# Altium schematic sheet Power outputs.SchDoc("Power outputs")
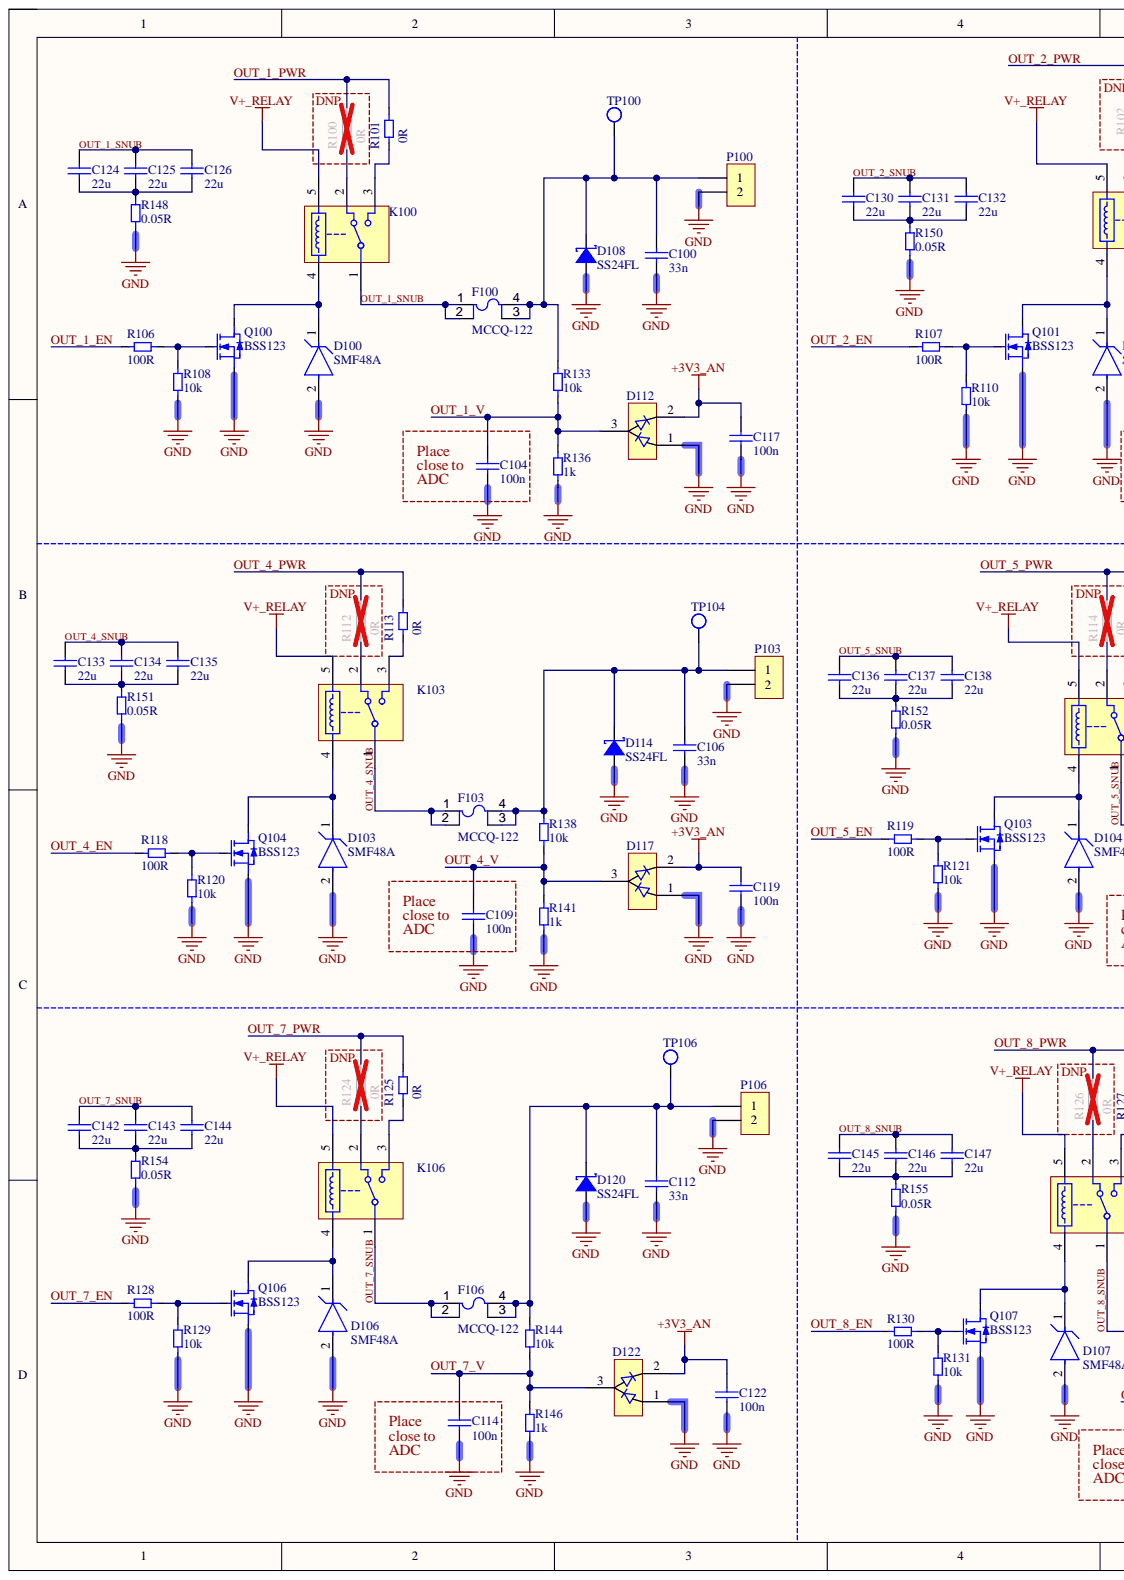
[diagram p0: Power outputs.SchDoc, left]
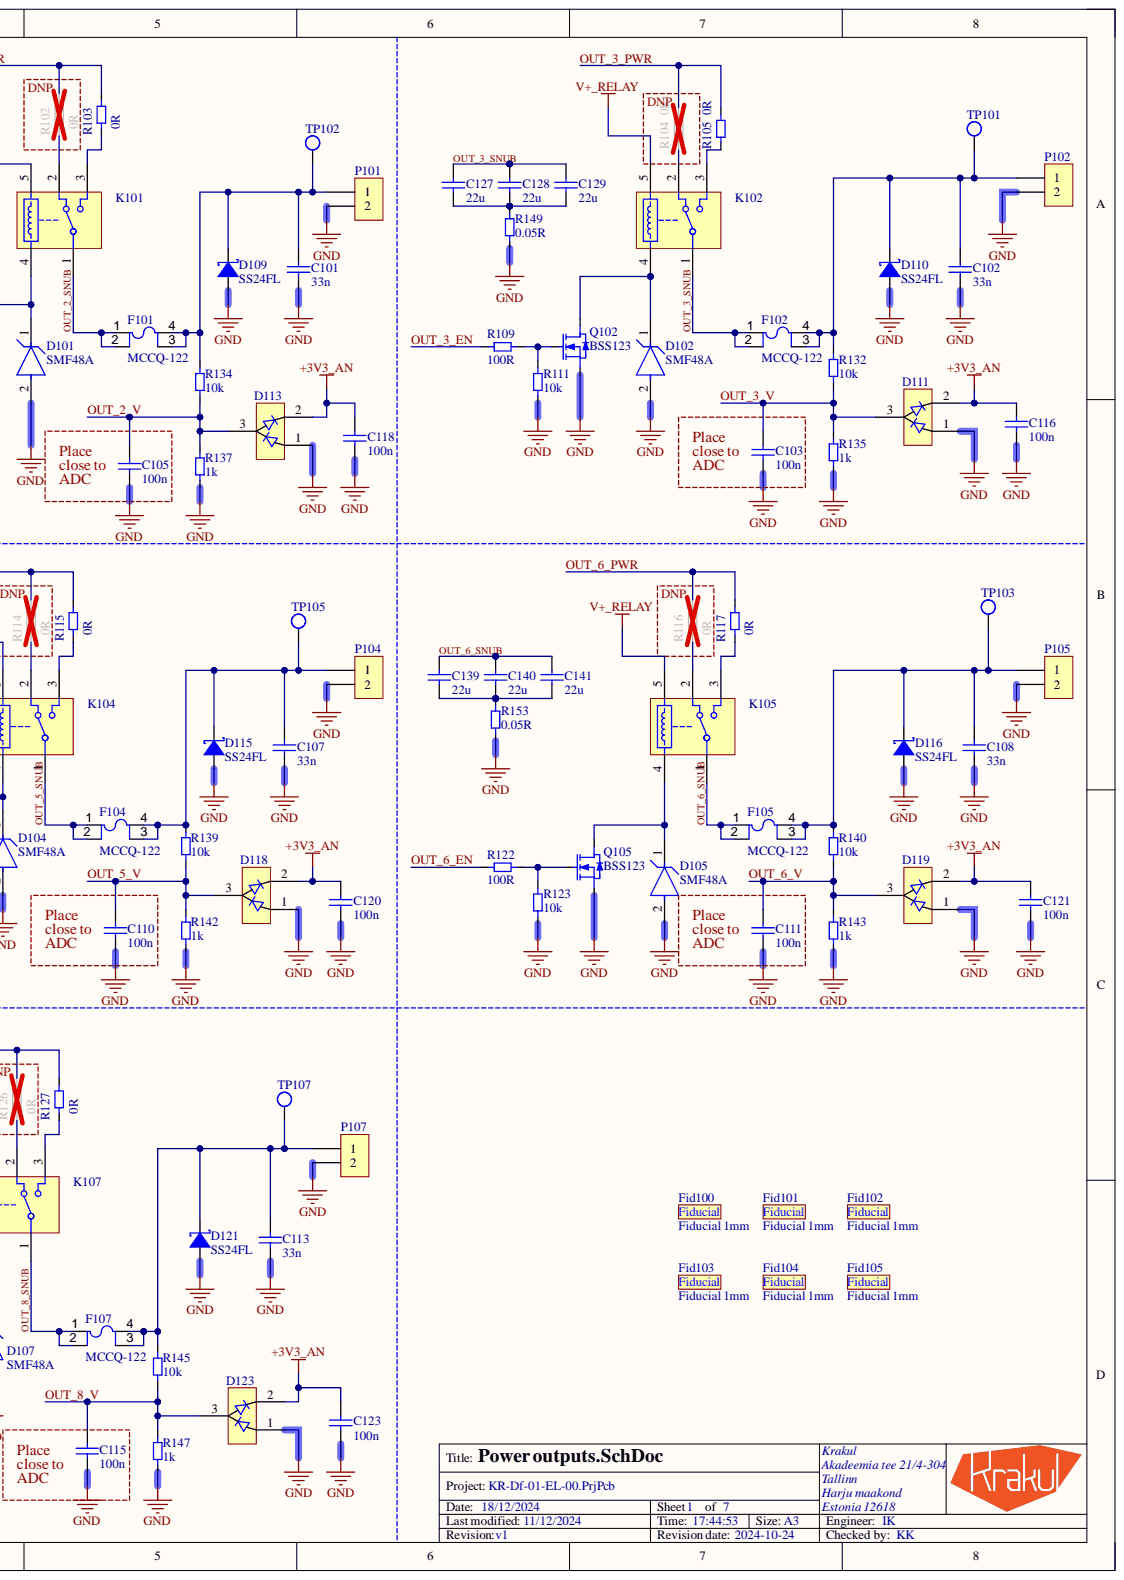
[diagram p1: Power outputs.SchDoc, right]

## Parts
C100  33n  [MLCC_0805_M]
C101  33n  [MLCC_0805_M]
C102  33n  [MLCC_0805_M]
C103  100n  [MLCC_0402_M]
C104  100n  [MLCC_0402_M]
C105  100n  [MLCC_0402_M]
C106  33n  [MLCC_0805_M]
C107  33n  [MLCC_0805_M]
C108  33n  [MLCC_0805_M]
C109  100n  [MLCC_0402_M]
C110  100n  [MLCC_0402_M]
C111  100n  [MLCC_0402_M]
C112  33n  [MLCC_0805_M]
C113  33n  [MLCC_0805_M]
C114  100n  [MLCC_0402_M]
C115  100n  [MLCC_0402_M]
C116  100n  [MLCC_0402_M]
C117  100n  [MLCC_0402_M]
C118  100n  [MLCC_0402_M]
C119  100n  [MLCC_0402_M]
C120  100n  [MLCC_0402_M]
C121  100n  [MLCC_0402_M]
C122  100n  [MLCC_0402_M]
C123  100n  [MLCC_0402_M]
C124  22u  [MLCC_0805_M]
C125  22u  [MLCC_0805_M]
C126  22u  [MLCC_0805_M]
C127  22u  [MLCC_0805_M]
C128  22u  [MLCC_0805_M]
C129  22u  [MLCC_0805_M]
C130  22u  [MLCC_0805_M]
C131  22u  [MLCC_0805_M]
C132  22u  [MLCC_0805_M]
C133  22u  [MLCC_0805_M]
C134  22u  [MLCC_0805_M]
C135  22u  [MLCC_0805_M]
C136  22u  [MLCC_0805_M]
C137  22u  [MLCC_0805_M]
C138  22u  [MLCC_0805_M]
C139  22u  [MLCC_0805_M]
C140  22u  [MLCC_0805_M]
C141  22u  [MLCC_0805_M]
C142  22u  [MLCC_0805_M]
C143  22u  [MLCC_0805_M]
C144  22u  [MLCC_0805_M]
C145  22u  [MLCC_0805_M]
C146  22u  [MLCC_0805_M]
C147  22u  [MLCC_0805_M]
D100  SMF48A  [FP-SMF48A-MFG]
D101  SMF48A  [FP-SMF48A-MFG]
D102  SMF48A  [FP-SMF48A-MFG]
D103  SMF48A  [FP-SMF48A-MFG]
D104  SMF48A  [FP-SMF48A-MFG]
D105  SMF48A  [FP-SMF48A-MFG]
D106  SMF48A  [FP-SMF48A-MFG]
D107  SMF48A  [FP-SMF48A-MFG]
D108  SS24FL  [SOD-123F]
D109  SS24FL  [SOD-123F]
D110  SS24FL  [SOD-123F]
D111  BAT6404WH6327XTSA1  [SOT323, SC70]  (value not drawn)
D112  BAT6404WH6327XTSA1  [SOT323, SC70]  (value not drawn)
D113  BAT6404WH6327XTSA1  [SOT323, SC70]  (value not drawn)
D114  SS24FL  [SOD-123F]
D115  SS24FL  [SOD-123F]
D116  SS24FL  [SOD-123F]
D117  BAT6404WH6327XTSA1  [SOT323, SC70]  (value not drawn)
D118  BAT6404WH6327XTSA1  [SOT323, SC70]  (value not drawn)
D119  BAT6404WH6327XTSA1  [SOT323, SC70]  (value not drawn)
D120  SS24FL  [SOD-123F]
D121  SS24FL  [SOD-123F]
D122  BAT6404WH6327XTSA1  [SOT323, SC70]  (value not drawn)
D123  BAT6404WH6327XTSA1  [SOT323, SC70]  (value not drawn)
F100  MCCQ-122  [MCCQ-122-Footprint-1]
F101  MCCQ-122  [MCCQ-122-Footprint-1]
F102  MCCQ-122  [MCCQ-122-Footprint-1]
F103  MCCQ-122  [MCCQ-122-Footprint-1]
F104  MCCQ-122  [MCCQ-122-Footprint-1]
F105  MCCQ-122  [MCCQ-122-Footprint-1]
F106  MCCQ-122  [MCCQ-122-Footprint-1]
F107  MCCQ-122  [MCCQ-122-Footprint-1]
Fid100  Fiducial 1mm  [Fiducial 1mm]
Fid101  Fiducial 1mm  [Fiducial 1mm]
Fid102  Fiducial 1mm  [Fiducial 1mm]
Fid103  Fiducial 1mm  [Fiducial 1mm]
Fid104  Fiducial 1mm  [Fiducial 1mm]
Fid105  Fiducial 1mm  [Fiducial 1mm]
K100  J107F1CS205VDC.45  [J107F1CS205VDC.45]  (value not drawn)
K101  J107F1CS205VDC.45  [J107F1CS205VDC.45]  (value not drawn)
K102  J107F1CS205VDC.45  [J107F1CS205VDC.45]  (value not drawn)
K103  J107F1CS205VDC.45  [J107F1CS205VDC.45]  (value not drawn)
K104  J107F1CS205VDC.45  [J107F1CS205VDC.45]  (value not drawn)
K105  J107F1CS205VDC.45  [J107F1CS205VDC.45]  (value not drawn)
K106  J107F1CS205VDC.45  [J107F1CS205VDC.45]  (value not drawn)
K107  J107F1CS205VDC.45  [J107F1CS205VDC.45]  (value not drawn)
P100  DGPS2.5R-5.0-02P  [DGPS2.5R-5.0-02P-Footprint-1]  (value not drawn)
P101  DGPS2.5R-5.0-02P  [DGPS2.5R-5.0-02P-Footprint-1]  (value not drawn)
P102  DGPS2.5R-5.0-02P  [DGPS2.5R-5.0-02P-Footprint-1]  (value not drawn)
P103  DGPS2.5R-5.0-02P  [DGPS2.5R-5.0-02P-Footprint-1]  (value not drawn)
P104  DGPS2.5R-5.0-02P  [DGPS2.5R-5.0-02P-Footprint-1]  (value not drawn)
P105  DGPS2.5R-5.0-02P  [DGPS2.5R-5.0-02P-Footprint-1]  (value not drawn)
P106  DGPS2.5R-5.0-02P  [DGPS2.5R-5.0-02P-Footprint-1]  (value not drawn)
P107  DGPS2.5R-5.0-02P  [DGPS2.5R-5.0-02P-Footprint-1]  (value not drawn)
Q100  BSS123  [SOT-23-3]
Q101  BSS123  [SOT-23-3]
Q102  BSS123  [SOT-23-3]
Q103  BSS123  [SOT-23-3]
Q104  BSS123  [SOT-23-3]
Q105  BSS123  [SOT-23-3]
Q106  BSS123  [SOT-23-3]
Q107  BSS123  [SOT-23-3]
R100  Value 2: 0R  [TLRZ2BTTD-Footprint-1]  (hidden comment: TLRZ2BTTD)
R101  Value 2: 0R  [TLRZ2BTTD-Footprint-1]  (hidden comment: TLRZ2BTTD)
R102  TLRZ2BTTD  [TLRZ2BTTD-Footprint-1]  (value not drawn)
R103  Value 2: 0R  [TLRZ2BTTD-Footprint-1]  (hidden comment: TLRZ2BTTD)
R104  Value 2: 0R  [TLRZ2BTTD-Footprint-1]  (hidden comment: TLRZ2BTTD)
R105  Value 2: 0R  [TLRZ2BTTD-Footprint-1]  (hidden comment: TLRZ2BTTD)
R106  100R  [Resistor_0603_M]
R107  100R  [Resistor_0603_M]
R108  10k  [Resistor_0603_M]
R109  100R  [Resistor_0603_M]
R110  10k  [Resistor_0603_M]
R111  10k  [Resistor_0603_M]
R112  TLRZ2BTTD  [TLRZ2BTTD-Footprint-1]  (value not drawn)
R113  Value 2: 0R  [TLRZ2BTTD-Footprint-1]  (hidden comment: TLRZ2BTTD)
R114  Value 2: 0R  [TLRZ2BTTD-Footprint-1]  (hidden comment: TLRZ2BTTD)
R115  Value 2: 0R  [TLRZ2BTTD-Footprint-1]  (hidden comment: TLRZ2BTTD)
R116  Value 2: 0R  [TLRZ2BTTD-Footprint-1]  (hidden comment: TLRZ2BTTD)
R117  Value 2: 0R  [TLRZ2BTTD-Footprint-1]  (hidden comment: TLRZ2BTTD)
R118  100R  [Resistor_0603_M]
R119  100R  [Resistor_0603_M]
R120  10k  [Resistor_0603_M]
R121  10k  [Resistor_0603_M]
R122  100R  [Resistor_0603_M]
R123  10k  [Resistor_0603_M]
R124  TLRZ2BTTD  [TLRZ2BTTD-Footprint-1]  (value not drawn)
R125  Value 2: 0R  [TLRZ2BTTD-Footprint-1]  (hidden comment: TLRZ2BTTD)
R126  Value 2: 0R  [TLRZ2BTTD-Footprint-1]  (hidden comment: TLRZ2BTTD)
R127  Value 2: 0R  [TLRZ2BTTD-Footprint-1]  (hidden comment: TLRZ2BTTD)
R128  100R  [Resistor_0603_M]
R129  10k  [Resistor_0603_M]
R130  100R  [Resistor_0603_M]
R131  10k  [Resistor_0603_M]
R132  10k  [Resistor_0603_M]
R133  10k  [Resistor_0603_M]
R134  10k  [Resistor_0603_M]
R135  1k  [Resistor_0603_M]
R136  1k  [Resistor_0603_M]
R137  1k  [Resistor_0603_M]
R138  10k  [Resistor_0603_M]
R139  10k  [Resistor_0603_M]
R140  10k  [Resistor_0603_M]
R141  1k  [Resistor_0603_M]
R142  1k  [Resistor_0603_M]
R143  1k  [Resistor_0603_M]
R144  10k  [Resistor_0603_M]
R145  10k  [Resistor_0603_M]
R146  1k  [Resistor_0603_M]
R147  1k  [Resistor_0603_M]
R148  0.05R  [0805 resisitor based on RCWE080550L0FNEA]
R149  0.05R  [0805 resisitor based on RCWE080550L0FNEA]
R150  0.05R  [0805 resisitor based on RCWE080550L0FNEA]
R151  0.05R  [0805 resisitor based on RCWE080550L0FNEA]
R152  0.05R  [0805 resisitor based on RCWE080550L0FNEA]
R153  0.05R  [0805 resisitor based on RCWE080550L0FNEA]
R154  0.05R  [0805 resisitor based on RCWE080550L0FNEA]
R155  0.05R  [0805 resisitor based on RCWE080550L0FNEA]
TP100  Testpoint 1.2mm  [Testpoint 1.2mm]  (value not drawn)  (no BOM)
TP101  Testpoint 1.2mm  [Testpoint 1.2mm]  (value not drawn)  (no BOM)
TP102  Testpoint 1.2mm  [Testpoint 1.2mm]  (value not drawn)  (no BOM)
TP103  Testpoint 1.2mm  [Testpoint 1.2mm]  (value not drawn)  (no BOM)
TP104  Testpoint 1.2mm  [Testpoint 1.2mm]  (value not drawn)  (no BOM)
TP105  Testpoint 1.2mm  [Testpoint 1.2mm]  (value not drawn)  (no BOM)
TP106  Testpoint 1.2mm  [Testpoint 1.2mm]  (value not drawn)  (no BOM)
TP107  Testpoint 1.2mm  [Testpoint 1.2mm]  (value not drawn)  (no BOM)

## Nets
+3V3_AN: C116.2 C117.2 C118.2 C119.2 C120.2 C121.2 C122.2 C123.2 D111.2 D112.2 D113.2 D117.2 D118.2 D119.2 D122.2 D123.2
GND: C100.1 C101.1 C102.1 C103.1 C104.1 C105.1 C106.1 C107.1 C108.1 C109.1 C110.1 C111.1 C112.1 C113.1 C114.1 C115.1 C116.1 C117.1 C118.1 C119.1 C120.1 C121.1 C122.1 C123.1 D100.2 D101.2 D102.2 D103.2 D104.2 D105.2 D106.2 D107.2 D108.2 D109.2 D110.2 D111.1 D112.1 D113.1 D114.2 D115.2 D116.2 D117.1 D118.1 D119.1 D120.2 D121.2 D122.1 D123.1 P100.2 P101.2 P102.2 P103.2 P104.2 P105.2 P106.2 P107.2 Q100.2 Q101.2 Q102.2 Q103.2 Q104.2 Q105.2 Q106.2 Q107.2 R108.1 R110.1 R111.1 R120.1 R121.1 R123.1 R129.1 R131.1 R135.1 R136.1 R137.1 R141.1 R142.1 R143.1 R146.1 R147.1 R148.1 R149.1 R150.1 R151.1 R152.1 R153.1 R154.1 R155.1
V+_RELAY: K100.5 K101.5 K102.5 K103.5 K104.5 K105.5 K106.5 K107.5
OUT_1_EN: R106.1
OUT_1_PWR: R100.2 R101.2
OUT_1_SNUB: C124.2 C125.2 C126.2 F100.1 F100.2 K100.1
OUT_1_V: C104.2 D112.3 R133.1 R136.2
OUT_2_EN: R107.1
OUT_2_PWR: R102.2 R103.2
OUT_2_SNUB: C130.2 C131.2 C132.2 F101.1 F101.2 K101.1
OUT_2_V: C105.2 D113.3 R134.1 R137.2
OUT_3_EN: R109.1
OUT_3_PWR: R104.2 R105.2
OUT_3_SNUB: C127.2 C128.2 C129.2 F102.1 F102.2 K102.1
OUT_3_V: C103.2 D111.3 R132.1 R135.2
OUT_4_EN: R118.1
OUT_4_PWR: R112.2 R113.2
OUT_4_SNUB: C133.2 C134.2 C135.2 F103.1 F103.2 K103.1
OUT_4_V: C109.2 D117.3 R138.1 R141.2
OUT_5_EN: R119.1
OUT_5_PWR: R114.2 R115.2
OUT_5_SNUB: C136.2 C137.2 C138.2 F104.1 F104.2 K104.1
OUT_5_V: C110.2 D118.3 R139.1 R142.2
OUT_6_EN: R122.1
OUT_6_PWR: R116.2 R117.2
OUT_6_SNUB: C139.2 C140.2 C141.2 F105.1 F105.2 K105.1
OUT_6_V: C111.2 D119.3 R140.1 R143.2
OUT_7_EN: R128.1
OUT_7_PWR: R124.2 R125.2
OUT_7_SNUB: C142.2 C143.2 C144.2 F106.1 F106.2 K106.1
OUT_7_V: C114.2 D122.3 R144.1 R146.2
OUT_8_EN: R130.1
OUT_8_PWR: R126.2 R127.2
OUT_8_SNUB: C145.2 C146.2 C147.2 F107.1 F107.2 K107.1
OUT_8_V: C115.2 D123.3 R145.1 R147.2
NetC100_2: C100.2 D108.1 F100.3 F100.4 P100.1 R133.2 TP100.1
NetC101_2: C101.2 D109.1 F101.3 F101.4 P101.1 R134.2 TP102.1
NetC102_2: C102.2 D110.1 F102.3 F102.4 P102.1 R132.2 TP101.1
NetC106_2: C106.2 D114.1 F103.3 F103.4 P103.1 R138.2 TP104.1
NetC107_2: C107.2 D115.1 F104.3 F104.4 P104.1 R139.2 TP105.1
NetC108_2: C108.2 D116.1 F105.3 F105.4 P105.1 R140.2 TP103.1
NetC112_2: C112.2 D120.1 F106.3 F106.4 P106.1 R144.2 TP106.1
NetC113_2: C113.2 D121.1 F107.3 F107.4 P107.1 R145.2 TP107.1
NetC124_1: C124.1 C125.1 C126.1 R148.2
NetC127_1: C127.1 C128.1 C129.1 R149.2
NetC130_1: C130.1 C131.1 C132.1 R150.2
NetC133_1: C133.1 C134.1 C135.1 R151.2
NetC136_1: C136.1 C137.1 C138.1 R152.2
NetC139_1: C139.1 C140.1 C141.1 R153.2
NetC142_1: C142.1 C143.1 C144.1 R154.2
NetC145_1: C145.1 C146.1 C147.1 R155.2
NetD100_1: D100.1 K100.4 Q100.3
NetD101_1: D101.1 K101.4 Q101.3
NetD102_1: D102.1 K102.4 Q102.3
NetD103_1: D103.1 K103.4 Q104.3
NetD104_1: D104.1 K104.4 Q103.3
NetD105_1: D105.1 K105.4 Q105.3
NetD106_1: D106.1 K106.4 Q106.3
NetD107_1: D107.1 K107.4 Q107.3
NetK100_2: K100.2 R100.1
NetK100_3: K100.3 R101.1
NetK101_2: K101.2 R102.1
NetK101_3: K101.3 R103.1
NetK102_2: K102.2 R104.1
NetK102_3: K102.3 R105.1
NetK103_2: K103.2 R112.1
NetK103_3: K103.3 R113.1
NetK104_2: K104.2 R114.1
NetK104_3: K104.3 R115.1
NetK105_2: K105.2 R116.1
NetK105_3: K105.3 R117.1
NetK106_2: K106.2 R124.1
NetK106_3: K106.3 R125.1
NetK107_2: K107.2 R126.1
NetK107_3: K107.3 R127.1
NetQ100_1: Q100.1 R106.2 R108.2
NetQ101_1: Q101.1 R107.2 R110.2
NetQ102_1: Q102.1 R109.2 R111.2
NetQ103_1: Q103.1 R119.2 R121.2
NetQ104_1: Q104.1 R118.2 R120.2
NetQ105_1: Q105.1 R122.2 R123.2
NetQ106_1: Q106.1 R128.2 R129.2
NetQ107_1: Q107.1 R130.2 R131.2

## Library data of the parts
C100-C102 C106-C108 C112 C113 = CMP-001-1076-2: MLCC, 0805, 33n, 10%, 50V, X7R; Manufacturer: Any; Capacitor Type: Ceramic; Value: 33nF; Case/Package: 0805; Component Kind: Standard; Dielectric: X7R; Mount: SMD; Part number: MLCC, 0805, 33n, 10%, 50V, X7R; RoHS: Yes; Tolerance: 10%; Voltage: 50V; Library Name: Krakul Vault
C103-C105 C109-C111 C114-C123 = CMP-001-1256-2: MLCC, 0402, 100n, 10%, 10V, X5R; Manufacturer: Any; Capacitor Type: Ceramic; Value: 100nF; Case/Package: 0402; Component Kind: Standard; Dielectric: X5R; Mount: SMD; Part number: MLCC, 0402, 100n, 10%, 10V, X5R; RoHS: Yes; Tolerance: 10%; Voltage: 10V; Library Name: Krakul Vault
C124-C147 = CMP-001-1665-1: MLCC, 0805, 22u, 20%, 35V, X5R; Manufacturer: TDK; Part number: C2012X5R1V226M125AC; Capacitor Type: Ceramic; Value: 22µF; Case/Package: 0805; Component Kind: Standard; Dielectric: X5R; Mount: SMD; RoHS: Yes; Tolerance: 20%; Voltage: 35V; Library Name: Krakul Vault
D100-D107 = CMP-ROOT-01053-2: Diode TVS Single Uni-Dir 48V 200W 2-Pin SOD-123F T/R; Manufacturer: Littelfuse; Breakdown Voltage: 58.9V; Clamping Voltage: 77.4V; Diode Type: ZENER; Max Surge Current: 2.6A; Min Breakdown Voltage: 53.3V; Number of Channels: 1; Polarity: Unidirectional; Power Line Protection: No; Case/Package: SMF; Component Kind: Standard; Lead Free: Contains Lead; Max Operating Temperature: 150°C; Min Operating Temperature: -55°C; Mount: SMD; Mounting Technology: Surface Mount; Number of Unidirectional Channels: 1; Packaging: Tape and Reel; Part number: SMF48A; Peak Pulse Current: 2.6A; Peak Pulse Power: 200W; Pins: 2; Reverse Standoff Voltage: 48V; RoHS: Yes; RoHS Compliant: Yes; Series: SMF; Termination: SMD/SMT; Library Name: Krakul Vault
D108-D110 D114-D116 D120 D121 = CMP-ROOT-00473-1: Diode Schottky 40V 2A Surface Mount SOD-123F; Manufacturer: ON Semiconductor; Element Configuration: Single; Max Forward Surge Current (Ifsm): 50A; Reverse Recovery Time: 9.495ns; Average Rectified Current: 2A; Case/Package: SOD; Component Kind: Standard; Max Repetitive Reverse Voltage (Vrrm): 40V; Max Reverse Voltage (DC): 40V; Mount: Surface Mount; Number of Pins: 2; Packaging: Tape and Reel; Part number: SS24FL; RoHS: Yes; Weight: 0.0276g; Library Name: Krakul Vault
D111-D113 D117-D119 D122 D123 = CMP-ROOT-00071-1: Diode Array 1 Pair Series Connection Schottky 40V 120mA Surface Mount SC-70, SOT-323; Manufacturer: Infineon; Forward Current: 250mA; Forward Voltage: 750mV; Peak Non-Repetitive Surge Current: 800mA; Reverse Recovery Time: 5ns; Average Rectified Current: 120mA; Case/Package: SOT-323; Component Kind: Standard; Halogen Free: Halogen Free; Lead Free: Lead Free; Max Operating Temperature: 150°C; Max Repetitive Reverse Voltage (Vrrm): 40V; Max Reverse Voltage (DC): 40V; Min Operating Temperature: -55°C; Mount: Surface Mount; Number of Pins: 3; Packaging: Tape and Reel; Part number: BAT6404WH6327XTSA1; Pins: 3; Radiation Hardening: No; RoHS: Yes; Library Name: Krakul Vault
F100-F107 = CMP-ROOT-01049-2: Fuseholder, Pcb Mount, Mini Blade; Manufacturer: Multicomp; Current Rating: 20A; Voltage Rating (AC): 250V; Case/Package: Holder; Component Kind: Standard; Fuse Current: 20A; Length: 14.7mm; Mount: SMD; Mounting Technology: Holder; Part number: MCCQ-122; Pins: 4; Reach SVHC Compliant: Unknown; RoHS: Yes; RoHS Compliant: Yes; Series: MINI; Voltage Rating (DC): 250V; Library Name: Krakul Vault
Fid100-Fid105 = CMP-00264-2: Component Kind: Standard; Part number: Fiducial 1mm; Library Name: Bolt Technology OÜ
K100-K107 = CMP-ROOT-01122-1: RELAY GEN PURPOSE SPDT 20A 5V; Manufacturer: CIT Relay and Switch; Case/Package: THT; Component Kind: Standard; Mount: SMD; Part number: J107F1CS205VDC.45; RoHS: Yes; Library Name: Krakul Vault
P100-P107 = CMP-ROOT-01059-1: Spring loaded terminal block; Manufacturer: Degson; Cross section: 2,5m2; Orderr Code: 10060008518; Pitch: 5.0mm; Rated Current: 20A; Rated Voltage: 400V; Component Kind: Standard; Mount: TH; Part number: DGPS2.5R-5.0-02P; Pins: 2; RoHS: Yes; Library Name: Krakul Vault
Q100-Q107 = CMP-ROOT-00113-5: MOSFET N-CH 100V 170MA SOT-23; Manufacturer: ON Semiconductor; Drain to Source Resistance: 6R; Element Configuration: Single; Fall Time: 9ns; Gate to Source Voltage (Vgs): 20V; Input Capacitance: 73pF; Max Power Dissipation: 360mW; Nominal Vgs: 1.7V; Number of Elements: 1; Turn-Off Delay Time: 17ns; Turn-On Delay Time: 1.7ns; Case/Package: SOT-23; Component Kind: Standard; Contact Plating: Tin,Matte; Continuous Drain Current (ID): 170mA; Current Rating: 170mA; Drain to Source Breakdown Voltage: 100V; Drain to Source Voltage (Vdss): 100V; Dual Supply Voltage: 100V; Height: 0.93mm; Lead Free: Lead Free; Length: 2.92mm; Max Operating Temperature: 150°C; Min Operating Temperature: -55°C; Mount: Surface Mount; Number of Pins: 3; On-State Resistance: 6R; Package Quantity: 1; Packaging: Tape and Reel; Part number: BSS123; Power Dissipation: 360mW; Radiation Hardening: No; Rds On Max: 6R; REACH SVHC: No SVHC; Rise Time: 9ns; RoHS: Yes; Threshold Voltage: 1.7V; Voltage: 100V; Voltage Rating (DC): 100V; Width: 1.3mm; Library Name: Krakul Vault
R100 R101 R103-R105 R113-R117 R125-R127 = CMP-0001-00276-2: Res Metal Plate 1206 0 Ohm Sulfur Resistant Pad SMD Automotive T/R; Manufacturer: KOA Speer Electronics; Current: 50A; Value: 0; Case Code (Imperial): 1206; Case Code (Metric): 3216; Case/Package: 1206; Component Kind: Standard; Features: Automotive AEC-Q200; Max Operating Temperature: 170°C; Min Operating Temperature: -55°C; Mount: SMD; Number of Terminations: 2; Packaging: Cut Tape; Part number: TLRZ2BTTD; Pins: 2; Ratings: AEC-Q200; RoHS: Yes; RoHS Compliant: Yes; Series: TLRZ; Library Name: Krakul Vault
R102 R112 R124 = CMP-0001-00276-2: Res Metal Plate 1206 0 Ohm Sulfur Resistant Pad SMD Automotive T/R; Manufacturer: KOA Speer Electronics; Current: 50A; Value: 0; Value 2: 0R; Case Code (Imperial): 1206; Case Code (Metric): 3216; Case/Package: 1206; Component Kind: Standard; Features: Automotive AEC-Q200; Max Operating Temperature: 170°C; Min Operating Temperature: -55°C; Mount: SMD; Number of Terminations: 2; Packaging: Cut Tape; Part number: TLRZ2BTTD; Pins: 2; Ratings: AEC-Q200; RoHS: Yes; RoHS Compliant: Yes; Series: TLRZ; Library Name: Krakul Vault
R106 R107 R109 R118 R119 R122 R128 R130 = CMP-001-0053-3: Resistor, 0603, 100R, 1%, 1/10W; Manufacturer: Any; Power: 100mW; Value: 100; Case/Package: 0603; Component Kind: Standard; Mount: SMD; Part number: Resistor, 0603, 100R, 1%, 1/10W; RoHS: Yes; Tolerance: 1%; Library Name: Krakul Vault
R108 R110 R111 R120 R121 R123 R129 R131-R134 R138-R140 R144 R145 = CMP-001-0101-2: Resistor, 0603, 10k, 1%, 1/10W; Manufacturer: Any; Power: 100mW; Case/Package: 0603; Component Kind: Standard; Mount: SMD; Part number: Resistor, 0603, 10k, 1%, 1/10W; RoHS: Yes; Tolerance: 1%; Value: 10k; Library Name: Krakul Vault
R135-R137 R141-R143 R146 R147 = CMP-0001-00237-1: Resistor, 0603, 1k, 0.1%, 1/16W; Manufacturer: Any; Case/Package: 0603; Component Kind: Standard; Mount: SMD; Part number: Any; RoHS: Yes; Value: 1k; Library Name: Krakul Vault
R148-R155 = CMP-0001-00285-1: SMD Chip Resistor, 50 MOhm, ± 1%, 250 mW, 0805 [2012 Metric], Thick Film, Anti-Sulfur; Manufacturer: Any; Part number: RCWE080550L0FNEA; Max Power Dissipation: 250mW; Temperature Coefficient: 200ppm/°C; Value: 50m; Case Code (Imperial): 0805; Case Code (Metric): 2012; Case/Package: 0805; Component Kind: Standard; Features: Moisture Resistant,Automotive AEC-Q200; Max Operating Temperature: 155°C; Min Operating Temperature: -55°C; Mount: SMD; Number of Terminations: 2; Packaging: Cut Tape; Ratings: AEC-Q200; RoHS: Yes; Series: RCWE; Tolerance: 1%; Library Name: Krakul Vault
TP100-TP107 = CMP-00265-4: Testpoint 1.2mm circular; Part number: Testpoint 1.2mm circular; Library Name: Bolt Technology OÜ
